# Altium schematic sheet uController.SchDoc
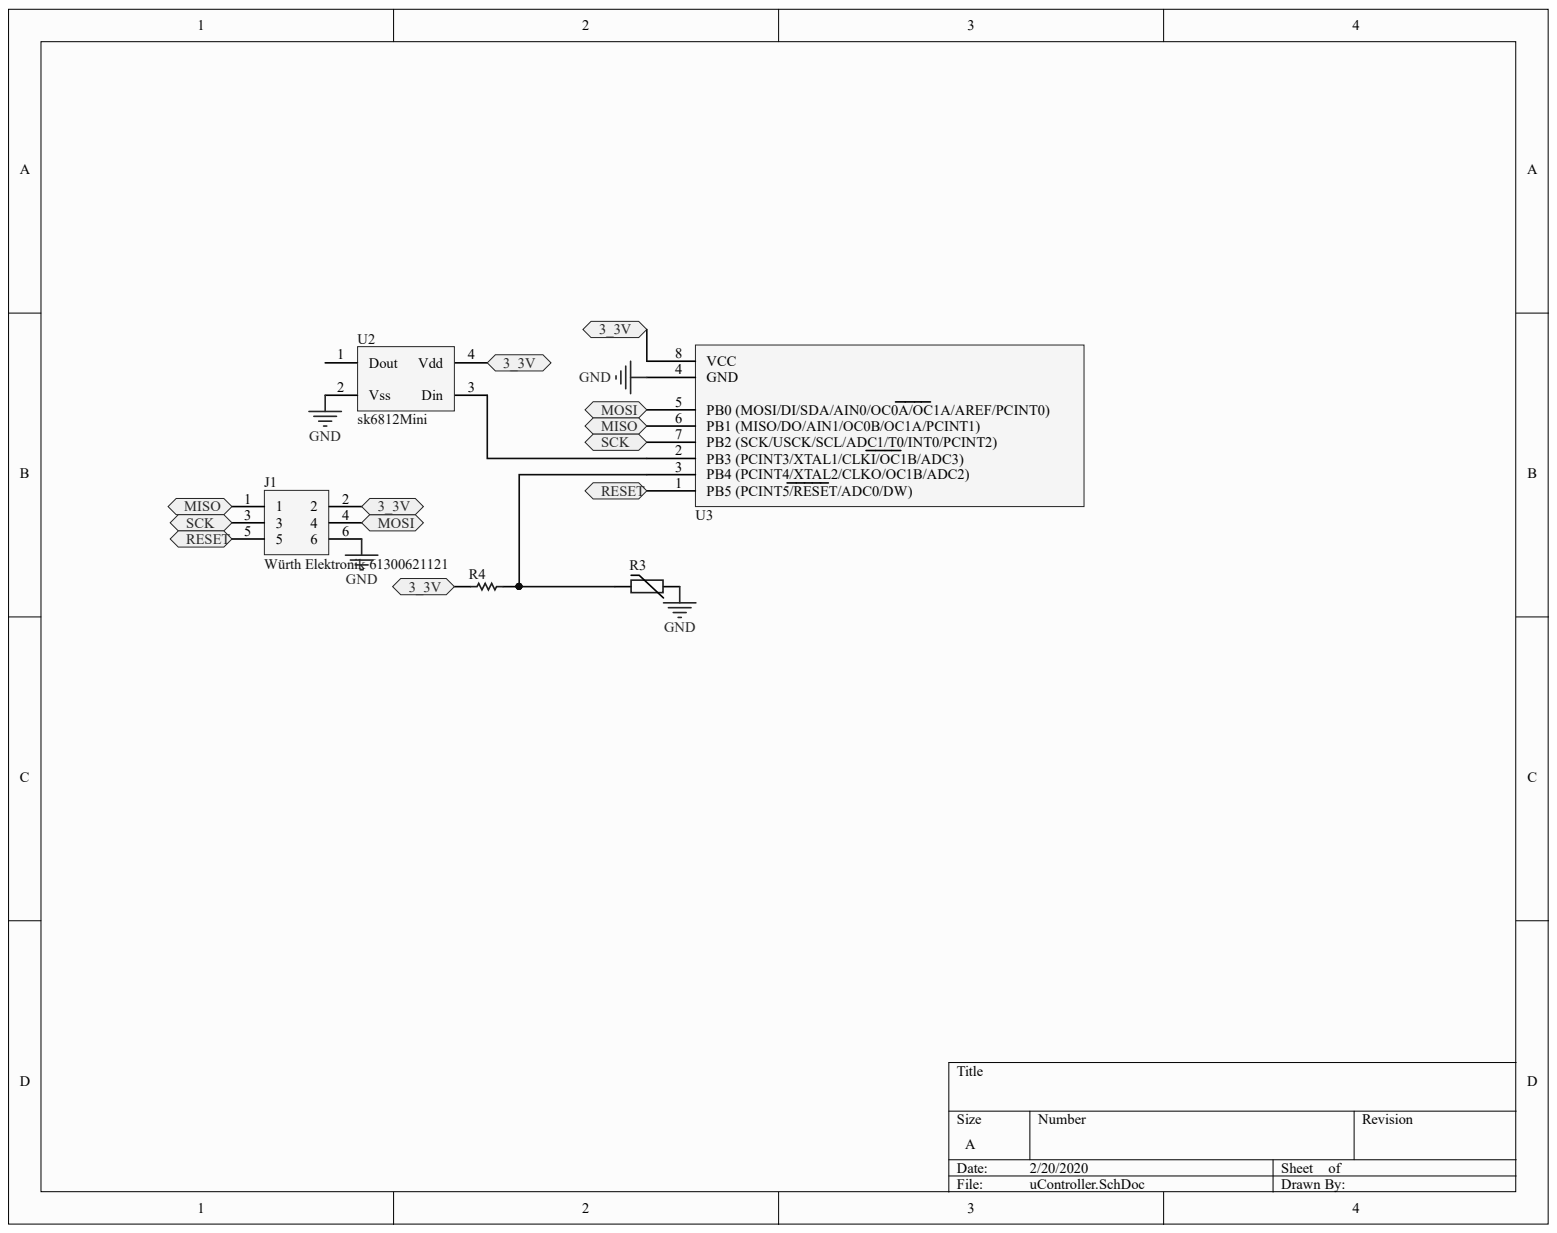
[diagram p0: uController.SchDoc]

## Parts
J1  Würth Elektronik 61300621121  Manufacturer Part Number: 61300621121  [PCB-zvoyfznuc9b5lzhitk0z-1]
R3  Vishay NTCS0603E3222FMT  [RESC1608X06N]  (value not drawn)
R4  Panasonic ERA-3YEB103V  [RESC1608X55X30NL20T20]  (value not drawn)
U2  sk6812Mini  [PCB-kt91jo9t2ht63m2p7oj6-1]
U3  Microchip 8-bit Microcontroller  [PCBComponent_1]  (value not drawn)

## Ports
3_3V, MISO, MOSI, RESET, SCK

## Nets
GND: J1.6 R3.2 U2.2(Vss) U3.4(GND)
3_3V: J1.2 R4.1 U2.4(Vdd) U3.8(VCC) ; port 3_3V
MISO: J1.1 U3.6(PB1_(MISO/DO/AIN1/OC0B/OC1A/PCINT1)) ; port MISO
MOSI: J1.4 U3.5(PB0_(MOSI/DI/SDA/AIN0/OC0A/OC1A/AREF/PCINT0)) ; port MOSI
RESET: J1.5 U3.1(PB5_(PCINT5/RESET/ADC0/DW)) ; port RESET
SCK: J1.3 U3.7(PB2_(SCK/USCK/SCL/ADC1/T0/INT0/PCINT2)) ; port SCK
NetR3_1: R3.1 R4.2 U3.3(PB4_(PCINT4/XTAL2/CLKO/OC1B/ADC2))
NetU2_3: U2.3(Din) U3.2(PB3_(PCINT3/XTAL1/CLKI/OC1B/ADC3))

Not connected: U2 1(Dout)

## Library data of the parts
J1 = CMP-8aac4253fa23556b-5: THT Vertical Pin Header WR-PHD, Pitch 2.54 mm, Dual Row, 6 pins; Current Rating: 3.00 A; Gender: Male; Number of Contacts: 6; Color: Black; Component Kind: Standard; Contact Material: Copper; Contact Plating: Gold; Library Name: CommunityVault; Mounting Style: Through Hole; OctopartID: 8aac4253fa23556b; Octopart URL: https://octopart.com/61300621121-w%C3%BCrth+elektronik-32855529; Operating Temperature: -40.0 °C (min); REACH SVHC Compliance: No SVHC
R3 = CMP-3832f3b29fa3e7f5-1: Thermistor NTC 2.2K Ohm 1% 2-Pin 0603 Surface Mount Solder Pad 3520K -4 to -3.5 T/R Automotive; Power Dissipation: 125 mW; Resistance: 2.20 k?; Case/Package: 0603; Component Kind: Standard; Library Name: FavoritesLibrary; Manufacturer Part Number: NTCS0603E3222FMT; Mounting Style: Surface Mount; OctopartID: 3832f3b29fa3e7f5; Octopart URL: https://octopart.com/ntcs0603e3222fmt-vishay-42631927; Operating Temperature: -40.0 °C (min); REACH SVHC Compliance: No SVHC; Resistance Tolerance: ±1%; RoHS: Compliant
R4 = CMP-3718261-2: Metal Film Resistors 10K   OHM  .1% 25PPM; Lead-Free Status: Lead Free; Power Rating: 0.063 W; Resistance: 10000.0 Ω; Resistance Tolerance: ±0.1%; Voltage Rating (DC): 75.0 V; Component Kind: Standard; Library Name: CommunityVault; Lifecycle Status: Not Listed by Manufacturer; Manufacturer Part Number: ERA-3YEB103V; Mounting Style: Surface Mount; OctopartID: b58c7fcbf3056a4b; Octopart Url: https://octopart.com/era-3yeb103v-panasonic-55403503; Packaging: Cut Tape (CT); RoHS: Compliant; Size-Height: 0.00045 m; Size-Length: 0.0016 m; Size-Width: 0.0008 m
U2 = CMP-531399334a2b8cd4-4: NEOPIXEL MINI 3535 RGB; Component Kind: Standard; Library Name: CommunityVault
U3 = CMP-1208391-4: MCU 8-bit ATtiny AVR RISC 8KB Flash 3.3V/5V 8-Pin SOIC EIAJ T/R; Manufacturer Part Number: ATTINY85-20SUR; Clock Speed: 20.0 MHz; Lead-Free Status: Lead Free; RAM Memory Size: 524288 B; Component Kind: Standard; Library Name: CommunityVault; Lifecycle Status: Not Listed by Manufacturer; Mounting Style: Surface Mount; OctopartID: ecab210346d1336c; Octopart URL: https://octopart.com/attiny85-20sur-microchip-77762332; Packaging: Cut Tape (CT); RoHS: Compliant
